# Altium schematic sheet Q1_STM32_Buck_PWR_Supply.SchDoc(Q1_STM32_Buck_PWR_Supply)
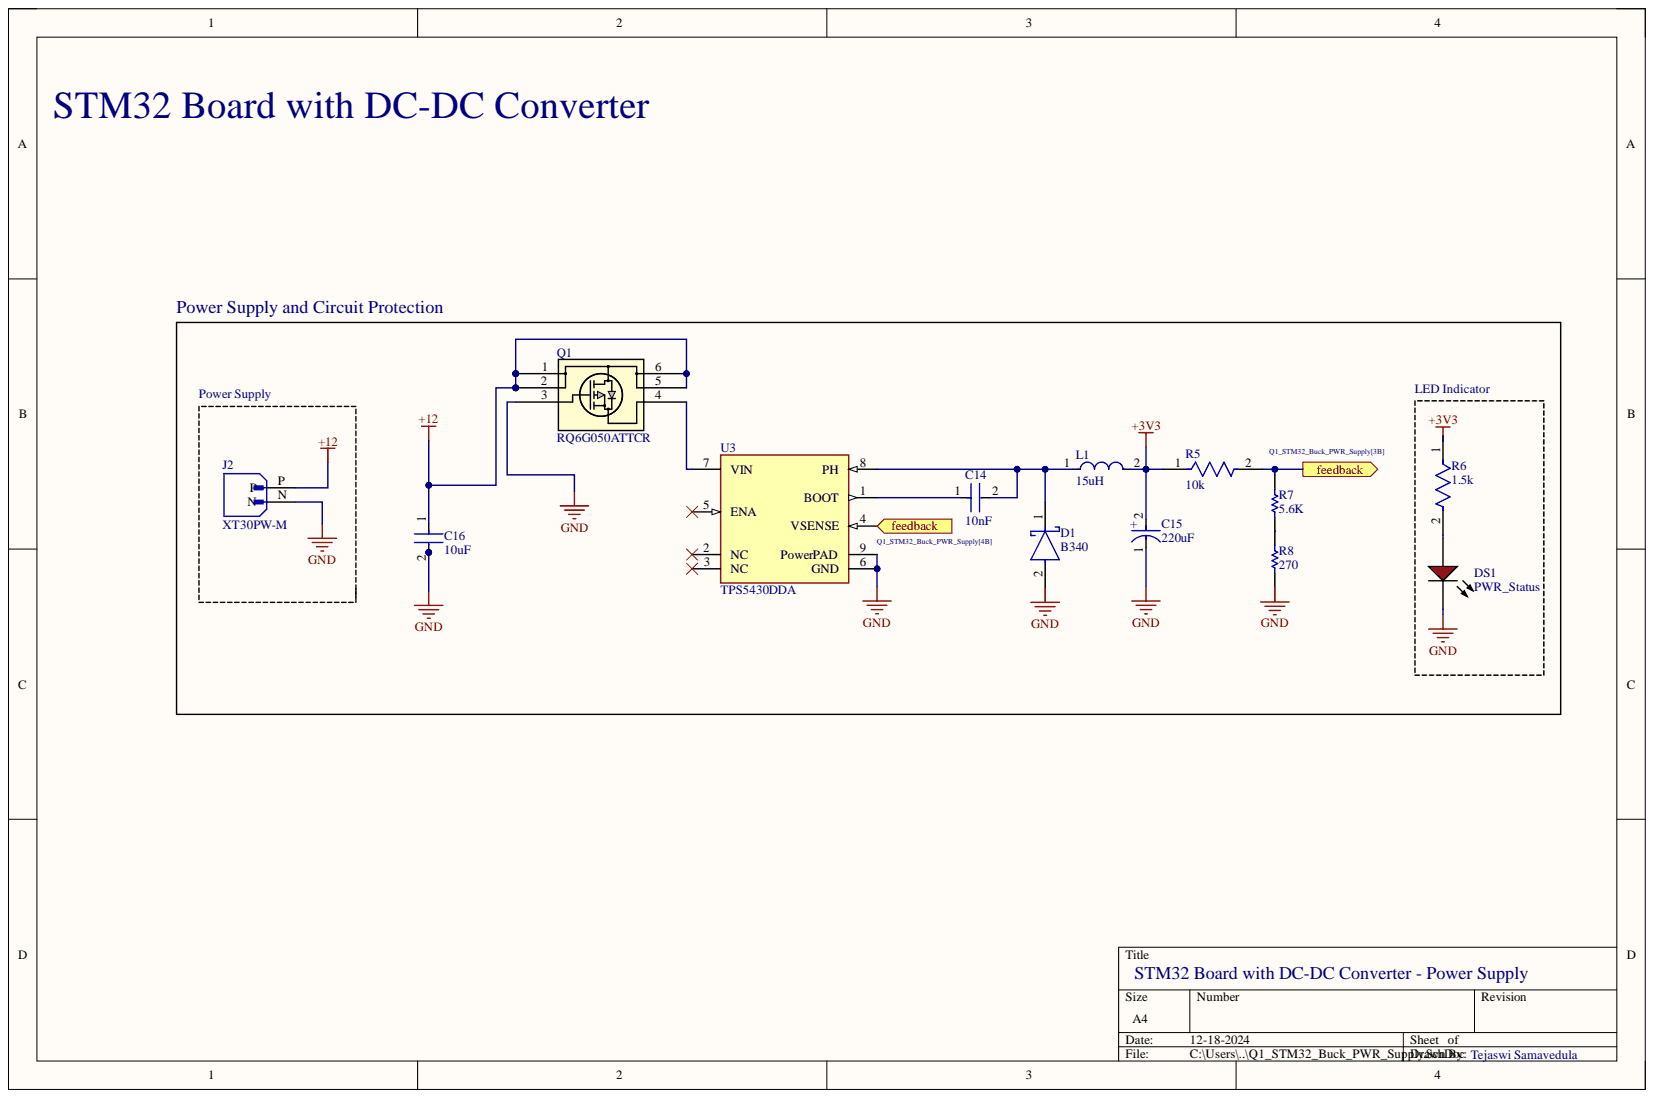
[diagram p0: Q1_STM32_Buck_PWR_Supply.SchDoc]

## Parts
C14  10nF  [FP-CC0402-MFG]
C15  220uF  [FP-POSCAP-D4-MFG]
C16  10uF  [FP-GRM188-0_1-e0_2_0_55-IPC_A]
D1  B340  [FP-SMA-MFG]
DS1  PWR_Status  [AVAG-HSMX-C150_V]
J2  XT30PW-M  [AMASS_XT30PW-M]
L1  15uH  [FP-SRR1260-MFG]
Q1  RQ6G050ATTCR  [SOT-457T_SC-95_ROM]
R5  10k  [FP-ERA2A-MFG]
R6  1.5k  [FP-ERA2A-MFG]
R7  5.6K  [RESC1005X40X25LL05T05]
R8  270  [RESC1005X40X25ML05T05]
U3  TPS5430DDA  [FP-DDA0008J-MFG]

## Ports
feedback

## Nets
+3V3: C15.2 L1.2 R5.1 R6.1
+12: C16.1 J2.P Q1.1 Q1.2 Q1.5 Q1.6
GND: C15.1 C16.2 D1.2 DS1.2 J2.N Q1.3 R8.1 U3.6(GND) U3.9(PowerPAD)
feedback: R5.2 R7.2 U3.4(VSENSE) ; port feedback
NetC14_1: C14.1 U3.1(BOOT)
NetC14_2: C14.2 D1.1 L1.1 U3.8(PH)
NetDS1_1: DS1.1 R6.2
NetQ1_4: Q1.4 U3.7(VIN)
NetR7_1: R7.1 R8.2

Not connected: U3 2(NC) 3(NC) 5(ENA)

## Library data of the parts
C14 = CMP-03422-001312-1: CAP CER 0.1UF 16V X5R 0402; Manufacturer: YAGEO; Manufacturer Part Number: CC0402MRX5R7BB104; Automotive Grade: NA; Material: Ceramic; Temperature Coefficient: 30ppm/°C; Tolerance: 0.2; Automotive: No; Capacitance: 10 nF; Category: Cap; Component Kind: Standard; ComponentLink2Description: Datasheet; ComponentLink2URL: http://www.yageo.com/documents/recent/UPY-GP_NP0_16V-to-50V_16.pdf; Device Class L1: Passive Components; Device Class L2: Capacitors; Device Class L3: Ceramic Capacitors; Digikey Description: CAP CER 0.1UF 16V X5R 0402; Footprint Url: http://www.yageo.com/exep/pages/download/literatures/UPY-C_GEN_24.pdf; Height: 0.55mm; Ipc Land Pattern Name: CAPC10050X50; Lead Free: Yes; Library Name: Altium Content Vault; Mouser Description: Multilayer Ceramic Capacitors MLCC - SMD/SMT 100nF 16V X5R 20%; Octopart: https://octopart.com/cc0402mrx5r7bb104-yageo-33242280; Package: CC0402; RoHS: TRUE; Temperature: -55°C to +125°C; Temperature Characteristic: X5R; Temperature Range High: +125°C; Temperature Range Low: -55°C; Value: 10 nF; Voltage Rating: 16 V
C15 = CMP-05428-000943-1: Molded Tantalum Polymer Capacitor 220uF 20% 10V life 2000Hours SMD 2917; Dissipation Factor: 10%; ESR (Equivalent Series Resistance): 40mR; Leakage Current: 220uA; Polarity: Polar; Ripple Current: 3A; Capacitance: 220uF; Case Code (Imperial): 2917; Case Code (Metric): 7343; Case/Package: 2917; Component Kind: Standard; Construction: Flat; Depth: 4.3mm; Features: General Purpose; Height: 3.8mm; Height - Seated (Max): 0.118inch; Lead Free: Lead Free; Length: 7.3mm; Library Name: Altium Content Vault; Material: Polymer; Max Operating Temperature: 105°C; Min Operating Temperature: -55°C; Mount: Surface Mount; Number of Pins: 4; Package Quantity: 2000; Packaging: Tape and Reel; Radiation Hardening: No; REACH SVHC: No SVHC; RoHS Compliant: Yes; Series: POSCAP™ TPB; Termination: SMD/SMT; Tolerance: 20%; Voltage: 10V; Voltage Rating: 10V; Voltage Rating (DC): 10V; Width: 4.3mm
C16 = CMP-2006-02792-2: Chip Multilayer Ceramic Capacitors for General Purpose, 0603, 10uF, X5R, 15%, 20%, 6.3V; Capacitance: 10uF; Case Code (Imperial): 0603; Case Code (Metric): 1608; Case/Package: 0603; Component Kind: Standard; Depth: 0.8mm; Dielectric: X5R; Height: 0.8mm; Lead Free: Lead Free; Length: 1.6mm; Library Name: Altium Content Vault; Material: Ceramic; Max Operating Temperature: 85°C; Min Operating Temperature: -55°C; Mount: Surface Mount; Package Quantity: 4000; Packaging: Tape and Reel; Radiation Hardening: No; REACH SVHC: No SVHC; Termination: SMD/SMT; Thickness: 0.035inch; Tolerance: 20%; Voltage: 6.3V; Voltage Rating: 6.3V; Voltage Rating (DC): 6.3V; Width: 0.031inch
D1 = CMP-1667-00003-3: DIODE SCHOTTKY 40V 3A SMA; Capacitance: 250pF; Element Configuration: Single; Forward Voltage: 500mV; Max Forward Surge Current (Ifsm): 80A; Max Reverse Current: 500uA; Polarity: Standard; Average Rectified Current: 3A; Case/Package: SMA; Component Kind: Standard; Contact Plating: Tin; Current: 3A; Current Rating: 3A; Forward Current: 3A; Height: 2.3mm; Lead Free: Lead Free; Length: 4.6mm; Library Name: Altium Content Vault; Max Operating Temperature: 125°C; Max Repetitive Reverse Voltage (Vrrm): 40V; Max Reverse Voltage (DC): 40V; Min Operating Temperature: -55°C; Mount: Surface Mount; Number of Pins: 2; Output Current: 3A; Package Quantity: 5000; Packaging: Tape and Reel; Peak Non-Repetitive Surge Current: 80A; Radiation Hardening: No; REACH SVHC: No SVHC; RoHS Compliant: Yes; Series: -; Termination: SMD/SMT; Voltage: 40V; Voltage Rating (DC): 40V; Weight: 0.002258oz; Width: 2.92mm
DS1 = CMP-2000-06426-1: LED Uni-Color Red, 65 mW, 25 mA, -40 to 85 degC, 2-Pin SMD, RoHS, Tape and Reel; Manufacturer: Avago; Chip Material: GaAlAs; DELETED: Compliant; Dominant Wave Length: 639nm; Forward Current: 25mA; Forward Voltage: 2.6V; High Brightness: No; Lens Type: ColorlessDiffused; Lum Intensity: 17mcd; Main Category: ChipLED; Number of  Elements: 1; Peak Wavelength: 660nm; Power Dissipation: 78W; Product Depth (mm): 1.6mm; Product Height (mm): 1.1mm; Product Length (mm): 3.2mm; Reverse Voltage: 5V; Shape Type: Rectangular; Test Current (It): 20mA; Viewing Angle: 170deg; Component Kind: Standard; Datasheet URL: http://media.digikey.com/pdf/Data%20Sheets/Avago%20PDFs/HSMz-Czzz.pdf; Datasheet Version: 03/2012; Emitting Color: Red; Lens Dimensions: 2x1.6x0.6mm; Library Name: Altium Content Vault; Luminous Intensity: 17mcd; Manufacturer URL: http://www.avagotech.com/; Mounting: SurfaceMount; Mounting Technology: SurfaceMount; Operating Temp Range: -40Cto85C; Operating Temperature Classification: Industrial; Package Description: 2-Pin Surface Mount Device, Body 3.2 x 1.6 mm; Package Reference: HSMX-C150; Package Type: ChipLed; Packaging: TapeandReel; Pin Count: 2; Rad Hardened: No; Type: Uni-Color
J2 = XT30PW-M: Socket; DC supply; XT30; male; PIN: 2; on PCBs; THT; Colour: yellow; MF: AMASS; Check_prices: https://www.snapeda.com/parts/XT30PW-M/AMASS/view-part/?ref=eda; Component Kind: Standard; Library Name: XT30PW-M.IntLib; MP: XT30PW-M; Package: None; SnapEDA_Link: https://www.snapeda.com/parts/XT30PW-M/AMASS/view-part/?ref=snap
L1 = CMP-2000-07146-2: FIXED IND 15UH 5A 27 MOHM SMD; Core Material: Ferrite; DC Resistance (DCR): 27mR; Frequency: 17.6MHz; Inductance Tolerance: 20%; Material: Steel; Q Factor: 25; Sample Rate (Hz): 100kHz; Saturation Current: 4.6A; Shielding: Shielded; Case/Package: 1260; Component Kind: Standard; Current Rating: 5A; DC Current: 5A; Depth: 12.8mm; Height: 6mm; Height - Seated (Max): 0.256inch; Inductance: 15uH; Length: 12.5mm; Library Name: Altium Content Vault; Max DC Current: 5A; Max Operating Temperature: 125°C; Military Standard: Not; Min Operating Temperature: -40°C; Mount: Surface Mount; Number of Pins: 2; Package Quantity: 600; Packaging: Tape and Reel; REACH SVHC: No SVHC; Resistance: 27MR; Resistor Type: Wirewound; RMS Current (Irms): 5A; RoHS Compliant: Yes; Self Resonant Frequency: 17.6MHz; Series: SRR1260; Termination: SMD/SMT; Test Frequency: 100kHz; Tolerance: 20%; Width: 12.5mm
Q1 = RQ6G050ATTCR: No Description Available; Mfr_Name: ROHM Semiconductor; Component Kind: Standard; Library Name: RQ6G050ATTCR.SchLib; RefDes: RefDes; Type: Type
R5 = CMP-2002-05875-2: RES SMD 10K OHM 0.1% 1/16W 0402; Manufacturer: Panasonic; Manufacturer Part Number: ERA-2AEB103X; Automotive Grade: Grade 0; Power Rating: 0.063W; Resistance: 10kΩ; Temperature Coefficient: 25ppm/°C; Value: 10kΩ; Voltage Rating: 50V; Automotive: Yes; Category: Res; Component Kind: Standard; ComponentLink2Description: Datasheet; ComponentLink2URL: https://industrial.panasonic.com/cdbs/www-data/pdf/RDM0000/AOA0000C307.pdf; Composition: Metal Film; Device Class L1: Passive Components; Device Class L2: Resistors; Device Class L3: Chip SMD Resistors; Digikey Description: RES SMD 10K OHM 0.1% 1/16W 0402; Footprint Url: https://industrial.panasonic.com/cdbs/www-data/pdf/RDM0000/DMM0000COL17.pdf; Height: 0.4mm; Lead Free: Yes; Library Name: Altium Content Vault; Mouser Description: Thin Film Resistors - SMD 0402 1/16W 10Kohms; Octopart: https://octopart.com/era-2aeb103x-panasonic-9251377; Package: ERA2A; RoHS: TRUE; Series: ERA; Temperature: -55°C to +155°C; Temperature Range High: +155°C; Temperature Range Low: -55°C; Tolerance: 0.1%
R6 = CMP-2002-05875-2: RES SMD 10K OHM 0.1% 1/16W 0402; Manufacturer: Panasonic; Manufacturer Part Number: ERA-2AEB103X; Automotive Grade: Grade 0; Power Rating: 0.063W; Resistance: 1.5kΩ; Temperature Coefficient: 25ppm/°C; Value: 1.5kΩ; Voltage Rating: 50V; Automotive: Yes; Category: Res; Component Kind: Standard; ComponentLink2Description: Datasheet; ComponentLink2URL: https://industrial.panasonic.com/cdbs/www-data/pdf/RDM0000/AOA0000C307.pdf; Composition: Metal Film; Device Class L1: Passive Components; Device Class L2: Resistors; Device Class L3: Chip SMD Resistors; Digikey Description: RES SMD 10K OHM 0.1% 1/16W 0402; Footprint Url: https://industrial.panasonic.com/cdbs/www-data/pdf/RDM0000/DMM0000COL17.pdf; Height: 0.4mm; Lead Free: Yes; Library Name: Altium Content Vault; Mouser Description: Thin Film Resistors - SMD 0402 1/16W 10Kohms; Octopart: https://octopart.com/era-2aeb103x-panasonic-9251377; Package: ERA2A; RoHS: TRUE; Series: ERA; Temperature: -55°C to +155°C; Temperature Range High: +155°C; Temperature Range Low: -55°C; Tolerance: 0.1%
R7 = CMP-2002-00121-1: Manufacturer: Vishay Dale; Part Number: CRCW04025K60FKED; Component Kind: Standard; Library Name: Altium Content Vault
R8 = CMP-2002-08427-1: Manufacturer: Vishay Dale; Part Number: CRCW0402270RFKED; Component Kind: Standard; Library Name: Altium Content Vault
U3 = CMP-2000-04960-2: IC REG BUCK ADJUSTABLE 3A 8SOPWR; Manufacturer: Texas Instruments; Max Duty Cycle: 89%; Max Junction Temp: +125°C; Max Supply Voltage: 36V; Min Supply Voltage: 5.5V; Nominal Supply Current: 3mA; Number Of Outputs: 1; Output Type: AdjustableProgrammable; Output Voltage: 1.23-31V; Standoff Height: 0mm; Switching Frequency: 500kHz; Automotive: No; Category: IC; Component Kind: Standard; ComponentLink2Description: Datasheet; ComponentLink2URL: http://www.ti.com/lit/ds/symlink/tps5431.pdf; Device Class L1: Integrated Circuits (ICs); Device Class L2: Power Management ICs; Device Class L3: Voltage Regulators - Switching; Digikey Description: IC REG BUCK ADJUSTABLE 3A 8SOPWR; Height: 1.7mm; Lead Free: Yes; Library Name: Altium Content Vault; Manufacturer Part Number: TPS5430DDA; Mouser Description: Voltage Regulators - Switching Regulators 5.5 to 36V Input 3A Step Dwn Converter; Octopart: https://octopart.com/tps5430dda-texas+instruments-589977; Output Current: 3A; Package: DDA0008J; RoHS: TRUE; Switching Topology: Buck; Temperature: -40°C to +125°C; Temperature Range High: +125°C; Temperature Range Low: -40°C
